# Altium schematic sheet 03_MSP430_AFE.SchDoc(03_MSP430_AFE)
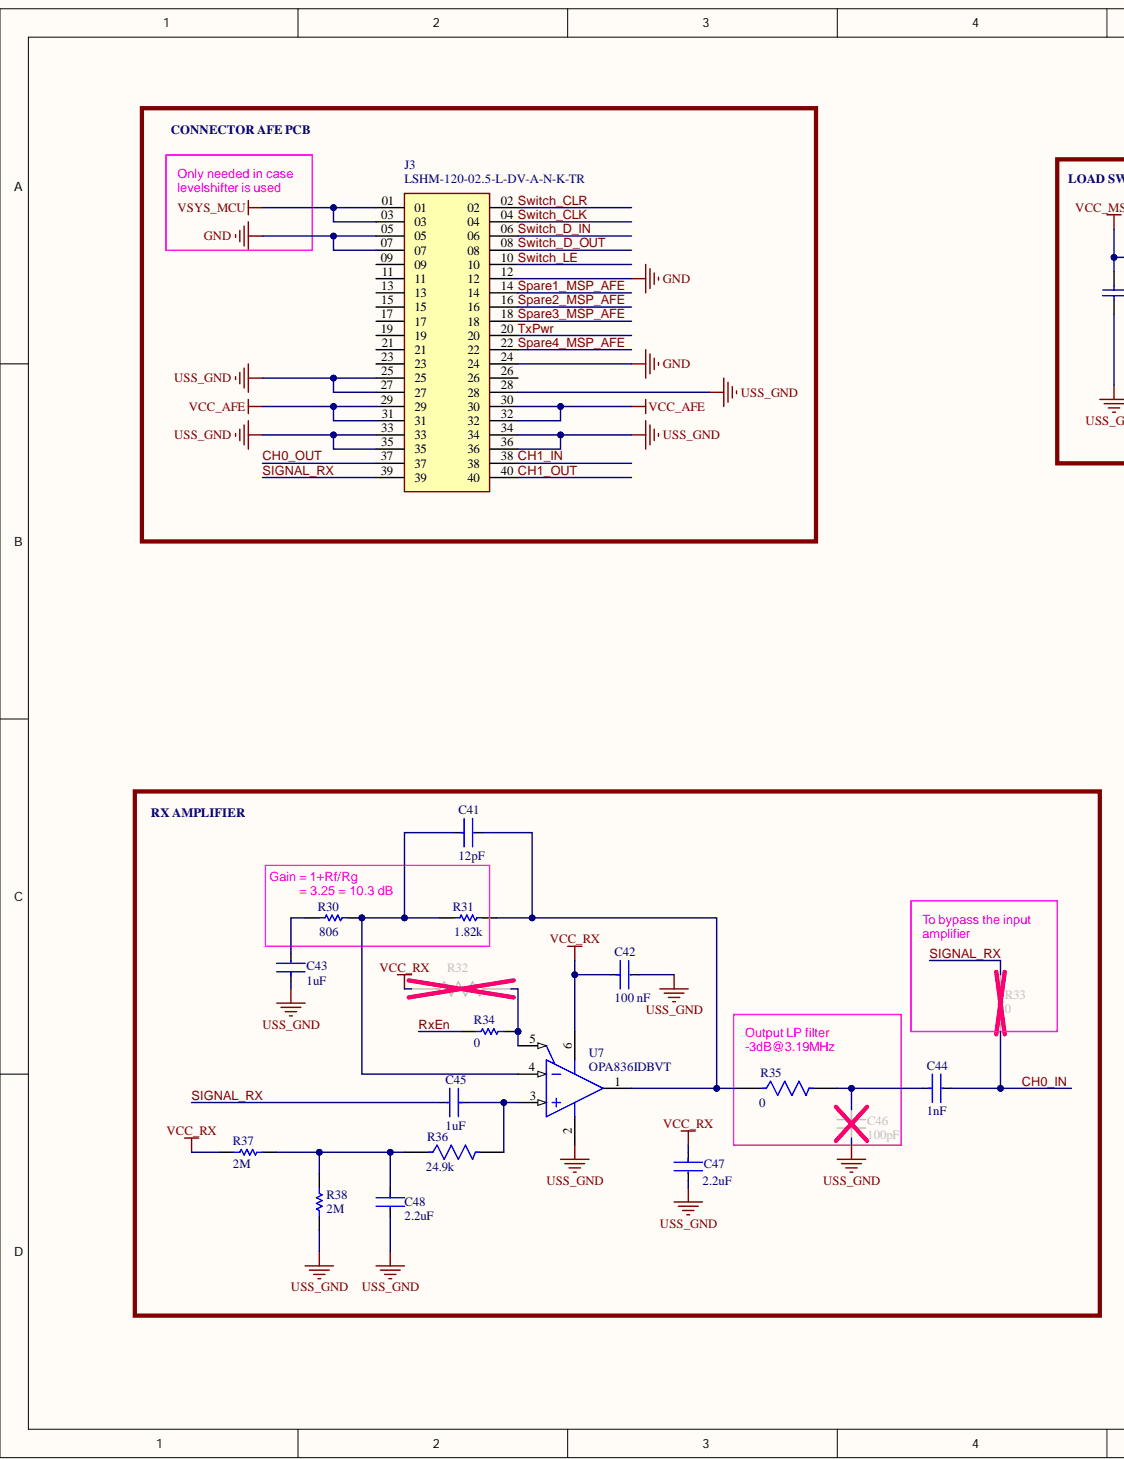
[diagram p0: 03_MSP430_AFE.SchDoc, left]
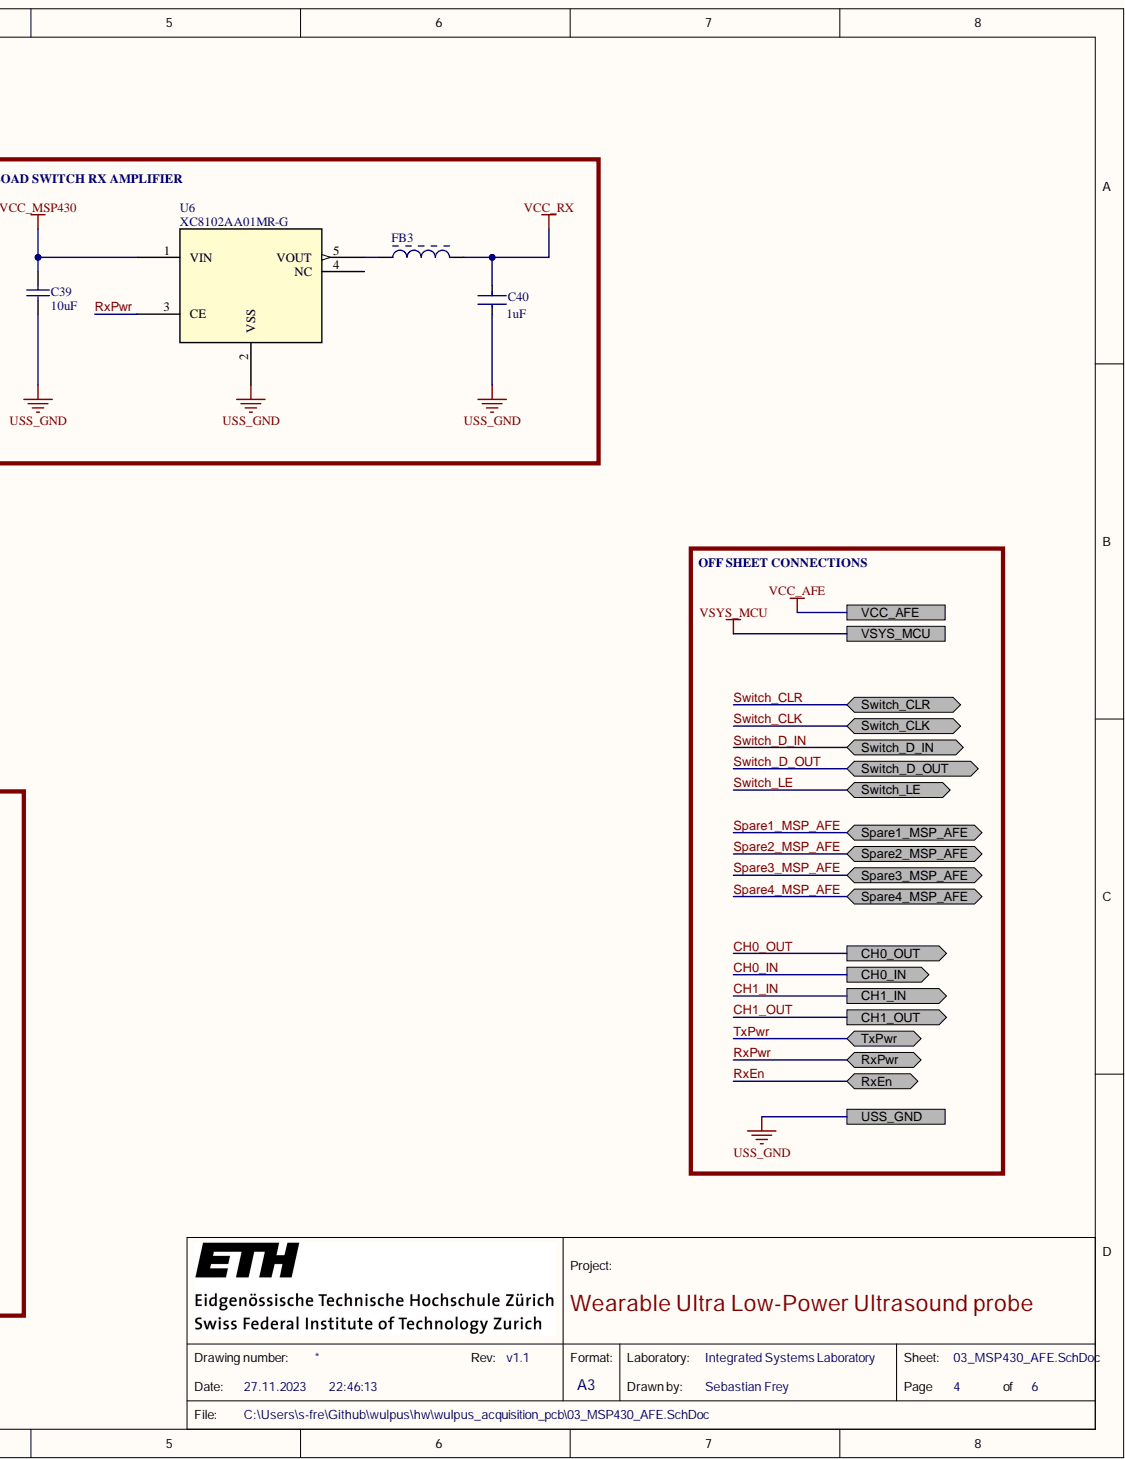
[diagram p1: 03_MSP430_AFE.SchDoc, right]

## Parts
C39  value 10uF  [CAPC0603(1608)100_L]  (hidden comment: CAP 10uF 10V 0603(1608))
C40  Capacitance: 1uF  [FP-C1608-080-0_1-IPC_B]  (hidden comment: C1608X7R1C105K080AC)
C41  Capacitance: 12pF  [FP-GJM155-0_05-IPC_A]  (hidden comment: GJM1555C1H120GB01D)
C42  value 100 nF  Capacitance: 100 nF  [FP-CC0402-MFG]  (hidden comment: CC0402KRX7R7BB104)
C43  Capacitance: 1uF  [FP-C1608-080-0_1-IPC_B]  (hidden comment: C1608X7R1C105K080AC)
C44  Capacitance: 1nF  [FP-GRM155-0_05-IPC_B]  (hidden comment: GRM1555C1H102JA01D)
C45  Capacitance: 1uF  [FP-C1608-080-0_1-IPC_B]  (hidden comment: C1608X7R1C105K080AC)
C46  Capacitance: 100pF  [FP-04025A101JAT2A-MFG]  (hidden comment: 04025A101JAT2A)
C47  Capacitance: 2.2uF  [FP-CGA3E-0_3-0_1-MFG]  (hidden comment: CGA3E1X5R1C225K080AC)
C48  Capacitance: 2.2uF  [FP-CGA3E-0_3-0_1-MFG]  (hidden comment: CGA3E1X5R1C225K080AC)
FB3  BLM03AX800SN1D  [MURA-BLM03-CHIP-2_V]  (value not drawn)
J3  LSHM-120-02.5-L-DV-A-N-K-TR  [SAMTEC_LSHM-120-XX.X-X-DV-A-N-XX]
R30  RC0603FR-07806RL  [RESC1608X55X25LL10T15]  (value not drawn)
R31  Resistance: 1.82k  [RESC1608X55X25ML10T15]  (hidden comment: RC0603FR-071K82L)
R32  TNPW040210K0BEED  [FP-TNPW0402-e3-MFG]  (value not drawn)
R33  RC0402JR-070RL  [RESC1005X40X25NL05T10]  (value not drawn)
R34  RC0402JR-070RL  [RESC1005X40X25NL05T10]  (value not drawn)
R35  CR0402-J/-000GLF  [FP-CR0402-IPC_B]  (value not drawn)
R36  Resistance: 24.9k  [FP-RC_L0603-MFG]  (hidden comment: RC0603FR-0724K9L)
R37  Parameter 1: 2M  [RESC1608X55X30LL15T15]  (hidden comment: ERJ-3EKF2004V)
R38  Parameter 1: 2M  [RESC1608X55X30LL15T15]  (hidden comment: ERJ-3EKF2004V)
U6  XC8102AA01MR-G  [XC8102_SOT-25_TOR]
U7  OPA836IDBVT  [DBV0006A_L]

## Ports
CH0_IN, CH0_OUT, CH1_IN, CH1_OUT, RxEn, RxPwr, Spare1_MSP_AFE, Spare2_MSP_AFE, Spare3_MSP_AFE, Spare4_MSP_AFE, Switch_CLK, Switch_CLR, Switch_D_IN, Switch_D_OUT, Switch_LE, TxPwr, USS_GND, VCC_AFE, VSYS_MCU

## Nets
GND: J3.05 J3.07 J3.12 J3.24
VCC_MSP430: C39.2 U6.1(VIN)
VCC_RX: C40.2 C42.1 C47.1 FB3.2 R32.1 R37.2 U7.6
CH0_IN: C44.2 R33.1 ; port CH0_IN
CH0_OUT: J3.37 ; port CH0_OUT
CH1_IN: J3.38 ; port CH1_IN
CH1_OUT: J3.40 ; port CH1_OUT
RxEn: R34.2 ; port RxEn
RxPwr: U6.3(CE) ; port RxPwr
SIGNAL_RX: C45.1 J3.39 R33.2
Spare1_MSP_AFE: J3.14 ; port Spare1_MSP_AFE
Spare2_MSP_AFE: J3.16 ; port Spare2_MSP_AFE
Spare3_MSP_AFE: J3.18 ; port Spare3_MSP_AFE
Spare4_MSP_AFE: J3.22 ; port Spare4_MSP_AFE
Switch_CLK: J3.04 ; port Switch_CLK
Switch_CLR: J3.02 ; port Switch_CLR
Switch_D_IN: J3.06 ; port Switch_D_IN
Switch_D_OUT: J3.08 ; port Switch_D_OUT
Switch_LE: J3.10 ; port Switch_LE
TxPwr: J3.20 ; port TxPwr
USS_GND: C39.1 C40.1 C42.2 C43.2 C46.1 C47.2 C48.2 J3.25 J3.27 J3.28 J3.33 J3.34 J3.35 J3.36 R38.1 U6.2(VSS) U7.2 ; port USS_GND
VCC_AFE: J3.29 J3.30 J3.31 J3.32 ; port VCC_AFE
VSYS_MCU: J3.01 J3.03 ; port VSYS_MCU
NetC41_1: C41.1 R30.2 R31.1 U7.4
NetC41_2: C41.2 R31.2 R35.1 U7.1
NetC43_1: C43.1 R30.1
NetC44_1: C44.1 C46.2 R35.2
NetC45_2: C45.2 R36.2 U7.3
NetC48_1: C48.1 R36.1 R37.1 R38.2
NetFB3_1: FB3.1 U6.5(VOUT)
NetR32_2: R32.2 R34.1 U7.5

Not connected: J3 09 11 13 15 17 19 21 23 26; U6 4(NC)

## Library data of the parts
C39 = CMP-1035-02799-1: CAP 10uF 10V ±10% 0603 (1608 Metric) Thickness 1mm SMD; Case-EIA: 0603; Case-Metric: 1608; Tolerance: Â±10%; Component Kind: Standard; Library Name: Altium Content Vault; Max Thickness: 1 mm; Rated Voltage: 10 V; Technology: SMT
C40 C43 C45 = CMP-08246-002727-1: Multilayer Ceramic Capacitors 1μF ±10% 16V X7R SMD 0603; Case Code (Imperial): 0603; Case Code (Metric): 1608; Case/Package: 0603; Component Kind: Standard; Construction: Flat; Depth: 0.8mm; Dielectric: X7R; Dielectric Material: Ceramic; Height: 0.8mm; Lead Free: Lead Free; Length: 1.6mm; Library Name: Altium Content Vault; Max Operating Temperature: 125°C; Min Operating Temperature: -55°C; Mount: Surface Mount; Package Quantity: 1; Packaging: Tape and Reel; Radiation Hardening: No; REACH SVHC: No SVHC; Resistor Type: Ceramic; RoHS Compliant: Yes; Series: C; Termination: SMD/SMT; Thickness: 0.037inch; Tolerance: 10%; Voltage: 16V; Voltage Rating: 16V; Voltage Rating (DC): 16V; Weight: 7.1E-05oz; Width: 0.031inch
C41 = CMP-2008-03799-2: Multi-Layer Ceramic Capacitor 12pF C0G  ±2% 0402 Paper T/R; Voltage Rating: 50V; Case Code (Imperial): 0402; Case Code (Metric): 1005; Case/Package: 0402; Component Kind: Standard; Depth: 0.5mm; Dielectric: C0G; Height: 0.5mm; Lead Free: Lead Free; Length: 1mm; Library Name: Altium Content Vault; Max Operating Temperature: 125°C; Min Operating Temperature: -55°C; Mount: Surface Mount; Package Quantity: 10000; Packaging: Tape and Reel; Radiation Hardening: No; Termination: SMD/SMT; Thickness: 0.022inch; Tolerance: 2%; Voltage Rating (DC): 50V; Width: 0.5mm
C42 = CMP-03422-000048-1: CAP CER 0.1UF 16V X7R 0402; Manufacturer: YAGEO; Automotive Grade: NA; Material: Ceramic; Temperature Coefficient: 30ppm/°C; Tolerance: 0.1; Automotive: No; Category: Cap; Component Kind: Standard; ComponentLink2Description: Datasheet; ComponentLink2URL: http://www.yageo.com/documents/recent/UPY-GP_NP0_16V-to-50V_16.pdf; Device Class L1: Passive Components; Device Class L2: Capacitors; Device Class L3: Ceramic Capacitors; Digikey Description: CAP CER 0.1UF 16V X7R 0402; Footprint Url: http://www.yageo.com/exep/pages/download/literatures/UPY-C_GEN_24.pdf; Height: 0.55mm; Ipc Land Pattern Name: CAPC10050X50; Lead Free: Yes; Library Name: Altium Content Vault; Manufacturer Part Number: CC0402KRX7R7BB104; Mouser Description: 0402 0.1 uF 16 V ±10 % Tolerance X7R SMT Multilayer Ceramic Capacitor; Octopart: https://octopart.com/cc0402krx7r7bb104-yageo-42244624; Package: CC0402; RoHS: TRUE; Temperature: -55°C to +125°C; Temperature Characteristic: X7R; Temperature Range High: +125°C; Temperature Range Low: -55°C; Voltage Rating: 16 V
C44 = CMP-2008-04254-2: Chip Multilayer Ceramic Capacitors for General Purpose, 0402, 1000pF, C0G, 30ppm/°C, 5%, 50V; Insulation Resistance: 10GR; Case Code (Imperial): 0402; Case Code (Metric): 1005; Case/Package: 0402; Component Kind: Standard; Depth: 0.5mm; Dielectric: C0G; Dielectric Material: Ceramic; Height: 0.5mm; Lead Free: Lead Free; Length: 1mm; Library Name: Altium Content Vault; Material: Ceramic; Max Operating Temperature: 125°C; Min Operating Temperature: -55°C; Mount: Surface Mount; Number of Pins: 2; Package Quantity: 1; Packaging: Tape and Reel; Radiation Hardening: No; REACH SVHC: No SVHC; Temperature Coefficient: 30ppm/°C; Termination: SMD/SMT; Thickness: 0.022inch; Tolerance: 5%; Voltage: 50V; Voltage Rating: 50V; Voltage Rating (DC): 50V; Width: 0.5mm
C46 = CMP-2008-02568-2: CAP CER 100PF 50V C0G/NP0 0402; Dielectric: C0G; Dissipation Factor: 2.5%; Insulation Resistance: 1GR; Temperature Coefficient: 30ppm/°C; Tolerance: 5%; Case Code (Imperial): 0402; Case Code (Metric): 1005; Case/Package: 0402; Component Kind: Standard; Construction: Flat; Contact Plating: Tin; Depth: 0.5mm; Height: 0.56mm; Lead Free: Lead Free; Length: 1mm; Library Name: Altium Content Vault; Max Operating Temperature: 125°C; Min Operating Temperature: -55°C; Mount: Surface Mount; Package Quantity: 1; Packaging: Tape and Reel; Radiation Hardening: No; REACH SVHC: No SVHC; RoHS Compliant: Yes; Series: -; Termination: SMD/SMT; Thickness: 0.022inch; Voltage: 50V; Voltage Rating: 50V; Voltage Rating (DC): 50V; Width: 0.02inch
C47 C48 = CMP-08246-002299-1: Cap Ceramic 2.2uF 16V X5R 10% Pad SMD 0603 +85°C Automotive T/R; Case Code (Imperial): 0603; Case Code (Metric): 1608; Case/Package: 0603; Component Kind: Standard; Composition: Ceramic; Depth: 0.8mm; Dielectric: X5R; Height: 0.8mm; Length: 1.6mm; Library Name: Altium Content Vault; Max Operating Temperature: 85°C; Min Operating Temperature: -55°C; Mount: Surface Mount; Package Quantity: 1; Packaging: Tape and Reel; Radiation Hardening: No; Ratings: AEC-Q200; REACH SVHC: No SVHC; Termination: SMD/SMT; Thickness: 0.035inch; Tolerance: 10%; Voltage Rating: 16V; Voltage Rating (DC): 16V; Weight: 7.1E-05oz; Width: 0.031inch
FB3 = CMP-0686-00058-2: Chip Ferrite Bead for Power lines and Signal Lines, 80 Ohm, 500 mA, -55 to 125 degC, 0.6 x 0.3 x 0.33 mm SMD, Tape and Reel; Manufacturer: Murata; Current Rating: 500mA; DC Resistance: 0.18 Ohm Max.; DC Resistance (DCR): 180mR; Impedance: 80R; Impedance (at 100 MHz): 80 Ohm +/- 25%; Material: Steel; Number of Circuits: 1; Rated Current: 500 mA; Test Frequency: 100MHz; Tolerance: 25%; Case Code (Imperial): 0201; Case Code (Metric): 0603; Case/Package: 0201; Category: Inductors; Component Kind: Standard; ComponentLink1Description: Manufacturer URL; ComponentLink1URL: http://www.murata.com/; ComponentLink2Description: Datasheet; ComponentLink2URL: http://www.murata.com/products/catalog/pdf/c31e.pdf; ComponentLink3Description: Package Specification; ComponentLink3URL: http://www.murata.com/products/catalog/pdf/c31e.pdf; ComponentLink4Description: https://datasheet.ciiva.com/1013/l0110s0100blm03ax-1013986.pdf?src-supplier=Digi-Key; ComponentLink4URL: https://datasheet.ciiva.com/1013/l0110s0100blm03ax-1013986.pdf?src-supplier=Digi-Key; ComponentLink5Description: https://datasheet.ciiva.com/41449/001172131-da-01-en-drossel-0201-80r-0-6x0-3mm-15000st-41449422.pdf?src-supplier=Conrad; ComponentLink5URL: https://datasheet.ciiva.com/41449/001172131-da-01-en-drossel-0201-80r-0-6x0-3mm-15000st-41449422.pdf?src-supplier=Conrad; ComponentLink6Description: https://datasheet.ciiva.com/1013/l0110e-1013987.pdf?src-supplier=Digi-Key; ComponentLink6URL: https://datasheet.ciiva.com/1013/l0110e-1013987.pdf?src-supplier=Digi-Key; ComponentLink7Description: https://datasheet.ciiva.com/1013/l0110e-1013988.pdf?src-supplier=Digi-Key; ComponentLink7URL: https://datasheet.ciiva.com/1013/l0110e-1013988.pdf?src-supplier=Digi-Key; ComponentLink8Description: https://datasheet.ciiva.com/46423/1851884-46423882.pdf?src-supplier=Element14; ComponentLink8URL: https://datasheet.ciiva.com/46423/1851884-46423882.pdf?src-supplier=Element14; DatasheetVersion: 08/2013; Height: 0.3mm; Length: 0.6mm; Library Name: Altium Content Vault; Manufacturer Part Number: BLM03AX800SN1D; Max Current Rating: 500mA; Max DC Current: 500mA; Max Operating Temperature: 125°C; Min Operating Temperature: -55°C; Mounting Technology: Surface Mount; Number of Lines: 1; Number of Pins: 2; Operating Temperature Range: -55 to 125 degC; Package Quantity: 1; PackageDescription: 2-Pin Chip, Body 0.6 x 0.3 mm; PackageReference: BLM03-2; Packaging: Tape and Reel; Packing: Tape and Reel; PartNumber: BLM03AX800SN1D; Pricing 1: 1=0.19000, 10=0.15200, 25=0.11840, 50=0.09820, 100=0.08470, 250=0.06776, 500=0.06098, 1000=0.05082, 5000=0.04743 (USD); REACH SVHC: No SVHC; RoHS: Yes; Stock 1: 13310; Supplier 1: Digi-Key; Supplier Part Number 1: 490-5621-1-ND; Termination: SMD/SMT; Width: 0.3mm
J3 = LSHM-120-02.5-L-DV-A-N-K-TR: Conn Socket and Terminal Strip HDR/SKT 40 POS 0.5mm Solder ST SMD T/R; Manufacturer: Samtec; Number of Contacts: 40; Category: Connectors; Component Kind: Standard; ComponentLink1Description: http://www.farnell.com/datasheets/2372721.pdf?src-supplier=Farnell; ComponentLink1URL: http://www.farnell.com/datasheets/2372721.pdf?src-supplier=Farnell; ComponentLink2Description: http://www.farnell.com/datasheets/2000266.pdf?src-supplier=Farnell; ComponentLink2URL: http://www.farnell.com/datasheets/2000266.pdf?src-supplier=Farnell; ComponentLink3Description: http://components.arrow.com/part/dataview/0323_01943898?src-supplier=Arrow+Europe; ComponentLink3URL: http://components.arrow.com/part/dataview/0323_01943898?src-supplier=Arrow+Europe; ComponentLink4Description: http://components.arrow.com/part/dataview/48680868S8397842N1004?src-supplier=Arrow+Electronics; ComponentLink4URL: http://components.arrow.com/part/dataview/48680868S8397842N1004?src-supplier=Arrow+Electronics; ComponentLink5Description: http://www.farnell.com/datasheets/2000266.pdf?src-supplier=Element14; ComponentLink5URL: http://www.farnell.com/datasheets/2000266.pdf?src-supplier=Element14; ComponentLink6Description: http://suddendocs.samtec.com/prints/lshm-1xx-xx.x-xx-dv-a-x-x-tr-mkt.pdf?src-supplier=Digi-Key; ComponentLink6URL: http://suddendocs.samtec.com/prints/lshm-1xx-xx.x-xx-dv-a-x-x-tr-mkt.pdf?src-supplier=Digi-Key; ComponentLink7Description: ftp://ftp.samtec.com/webfiles/PDF/LSHM.PDF?src-supplier=Avnet; ComponentLink7URL: ftp://ftp.samtec.com/webfiles/PDF/LSHM.PDF?src-supplier=Avnet; ComponentLink8Description: ftp://ftp.samtec.com/webfiles/PDF/LSHM.PDF?src-supplier=Avnet+Europe; ComponentLink8URL: ftp://ftp.samtec.com/webfiles/PDF/LSHM.PDF?src-supplier=Avnet+Europe; ComponentLink9Description: http://www.farnell.com/datasheets/2372721.pdf?src-supplier=Newark; ComponentLink9URL: http://www.farnell.com/datasheets/2372721.pdf?src-supplier=Newark; Contact Material: Bronze; Contact Plating: Gold; Depth: 4.98mm; Housing Color: Black; Housing Material: Polymer; Length: 14.7mm; Library Name: Schlib1.SchLib; Manufacturer Part Number: LSHM-120-02.5-L-DV-A-N-K-TR; Max Operating Temperature: 125°C; Min Operating Temperature: -55°C; Number of Rows: 2; Orientation: Straight; Packaging: Tape and Reel; Pitch: 0.5mm; Pricing 1: 1=6.60000, 10=5.97000, 25=5.63960, 50=5.50200, 100=5.22690, 250=4.67672 (USD); Radiation Hardening: No; REACH SVHC: No SVHC; RoHS: Yes; RoHS Compliant: Yes; Series: LSH; Stock 1: 3008; Supplier 1: Digi-Key; Supplier Part Number 1: SAM13196CT-ND; Termination: Solder
R30 = CMP-2000-04142-1: Manufacturer: Yageo; Resistance: 806; Component Kind: Standard; Library Name: Altium Content Vault; Part Number: RC0603FR-07806RL
R31 = CMP-2000-03938-1: Manufacturer: Yageo; Component Kind: Standard; Library Name: Altium Content Vault; Part Number: RC0603FR-071K82L
R32 = CMP-2002-01683-2: RES 10K OHM 0.1% 1/10W 0402; Manufacturer: Vishay; Automotive Grade: Grade 0; Power Rating: 0.1W; Resistance: 10kΩ; Temperature Coefficient: 25ppm/°C; Voltage Rating: 50V; Automotive: Yes; Category: Res; Component Kind: Standard; ComponentLink2Description: Datasheet; ComponentLink2URL: http://www.vishay.com/docs/28758/tnpw_e3.pdf; Composition: Thin Film; Device Class L1: Passive Components; Device Class L2: Resistors; Device Class L3: Chip SMD Resistors; Digikey Description: RES 10K OHM 0.1% 1/10W 0402; Height: 0.4mm; Ipc Land Pattern Name: RESC10050X35; Lead Free: Yes; Library Name: Altium Content Vault; Manufacturer Part Number: TNPW040210K0BEED; Octopart: https://octopart.com/tnpw040210k0beed-vishay-41229038; Package: TNPW0402-e3; RoHS: TRUE; Series: TNPW; Temperature: -55°C to +155°C; Temperature Range High: +155°C; Temperature Range Low: -55°C; Tolerance: 0.1%; Value: 10k
R33 R34 = CMP-1011-00001-2: Manufacturer: Yageo / Phycomp; Resistance: 0; Component Kind: Standard; Library Name: Altium Content Vault; Part Number: RC0402JR-070RL
R35 = CMP-2002-05495-2: Thick Film Chip Resistors 0402 0Ω 0.0625W 5%; Max Power Dissipation: 63mW; Power Rating: 62.5mW; Resistance: 0; Temperature Coefficient: 500ppm/°C; Voltage Rating: 50V; Case Code (Imperial): 0402; Case Code (Metric): 1005; Case/Package: 0402; Component Kind: Standard; Composition: Thick Film; Contact Plating: Tin; Depth: 0.5mm; Height: 0.35mm; Length: 1mm; Library Name: Altium Content Vault; Max Operating Temperature: 125°C; Min Operating Temperature: -55°C; Mount: Surface Mount; Number of Pins: 2; Package Quantity: 10000; Packaging: Tape and Reel; Radiation Hardening: No; REACH SVHC: No SVHC; Termination: SMD/SMT; Thickness: 356um; Tolerance: 5%; Voltage Rating (DC): 50V; Width: 0.5mm
R36 = CMP-03412-013083-1: RES SMD 24.9K OHM 1% 1/10W 0603; Approved Alternate Part Number: 541-1.00HHCT-ND; Manufacturer: Yageo; Power: 100mW; Power Rating: 0.1W; Temperature Coefficient: 100ppm/°C; Value: 24.9kΩ; Voltage: 75V; Automotive: No; Category: Res; Component Kind: Standard; ComponentLink2Description: Datasheet; ComponentLink2URL: https://www.yageo.com/upload/media/product/productsearch/datasheet/rchip/PYu-RC_Group_51_RoHS_L_10.pdf; Composition: Thick Film; Device Class L1: Passive Components; Device Class L2: Resistors; Device Class L3: Chip SMD Resistors; Digikey Description: RES SMD 24.9K OHM 1% 1/10W 0603; Footprint Url: https://www.yageo.com/upload/website/yageo_PYu-R_Mount_10_19050818_343.pdf; Height: 0.55mm; Ipc Land Pattern Name: RESC16080X45; Lead Free: Yes; Library Name: Altium Content Vault; Manufacturer Part Number: RC0603FR-0724K9L; Mouser Description: Res Thick Film 1.6 x 0.8 mm 24.9K Ohm 1% 0.1W(1/10W) 100ppm/ C Molded SMD Paper T/R; Octopart: https://octopart.com/rc0603fr-0724k9l-yageo-1189838; Package: RC_L0603; RoHS: TRUE; Series: RC_L; Temperature: -55°C to +155°C; Temperature Range High: +155°C; Temperature Range Low: -55°C; Tolerance: 1%; Voltage Rating: 75V
R37 R38 = CMP-2000-00320-1: Manufacturer: Panasonic; Component Kind: Standard; Library Name: Altium Content Vault; Part Number: ERJ-3EKF2004V
U6 = XC8102AA01MR-G: No Description Available; Mfr_Name: Torex Semiconductor; Component Kind: Standard; Library Name: Schlib1.SchLib; Manufacturer_Part_Number: XC8102AA01MR-G; RefDes: RefDes; Type: DEV; Copyright: Copyright (C) 2021 Ultra Librarian. All rights reserved.
U7 = CMP-0018-00480-2: Very Low Power, Rail to Rail Out, Negative Rail In, VFB Operational Amplifier, 2.5 to 5.5 V, -40 to 125 degC, 6-pin SOT23 (DBV6), Green (RoHS & no Sb/Br); Manufacturer: Texas Instruments; 2nd Harmonic(dBc): 85; 3rd Harmonic(dBc): 105; @ MHz: 1; BW @ Acl(MHz): 205; Iib(Max)(nA): 1000; Io(Typ)(mA): 50; Settling Time (0.1%)(Typ)(ns): 30; Slew Rate(Typ)(V/us): 560; Sub Family: Audio Operational Amplifier, High Output Current Amplifier (>=50mA), High Speed Amplifier (>=50MHz), Low Noise Amp (<=10nV/rtHz), Low Offset Voltage Amplifier (<=500uV), Low Supply Voltage Amplifier (<=2.7V); Vio(Max)(mV): 0.4; Vn at Flatband(Typ)(nV/rtHz): 4.6; Vs(Max)(V): 5.5; Vs(Min)(V): 2.5; Acl, min stable gain(V/V): 1; Code_JEDEC: MO-178; Component Kind: Standard; ComponentLink1Description: Manufacturer URL; ComponentLink1URL: http://www.ti.com/; ComponentLink2Description: Datasheet; ComponentLink2URL: http://www.ti.com/general/docs/lit/getliterature.tsp?genericPartNumber=OPA836&fileType=pdf; ComponentLink3Description: Package Specification; ComponentLink3URL: http://www.ti.com/litv/pdf/mpds026h; DatasheetVersion: SLOS712D; Eco-Plan: Green (RoHS & no Sb/Br); Iq per channel(Max)(mA): 1; Library Name: Altium Content Vault; Mounting Technology: Surface Mount; Number of Channels: 1; PackageDescription: 6-Pin Plastic Small Outline, Body 1.6 x 2.9 mm, Pitch 0.95 mm; PackageReference: DBV0006A; PackageVersion: 4073253-5/K, 03/2006; PartNumber: OPA836IDBVT
